# Altium schematic sheet uC.SchDoc
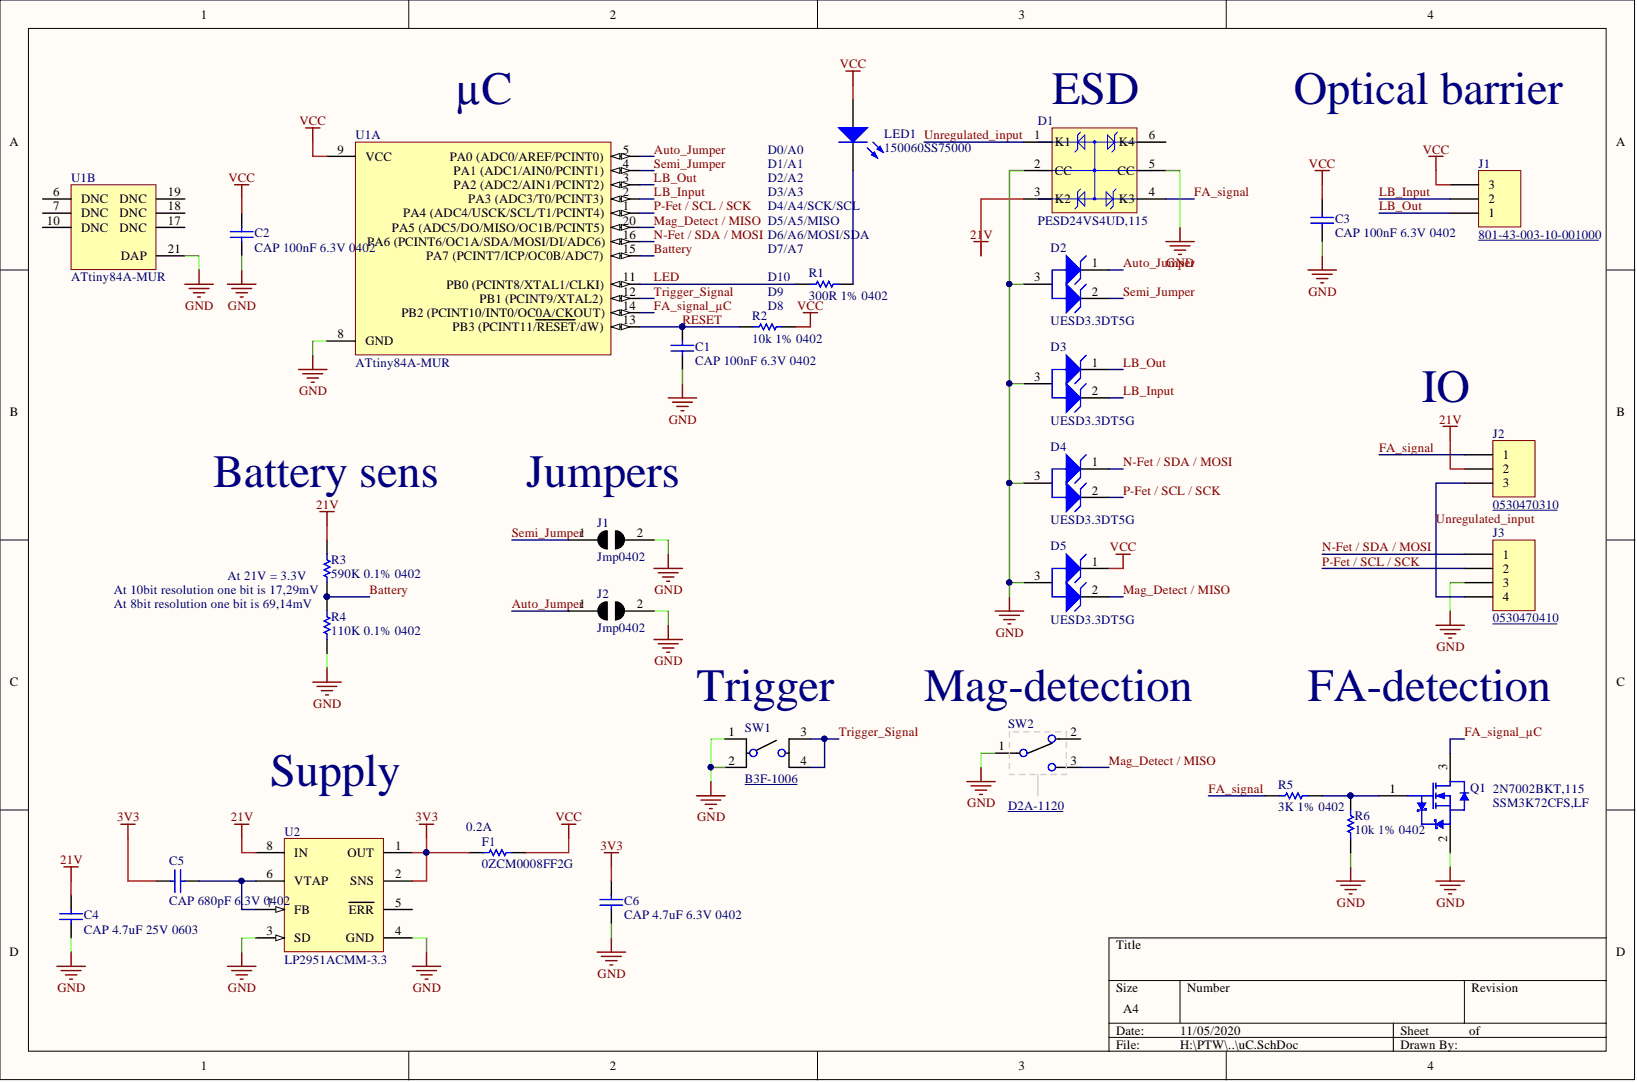
[diagram p0: uC.SchDoc]

## Parts
C1  CAP 100nF 6.3V 0402  value 100nF  [CAPC0402(1005)60_N]
C2  CAP 100nF 6.3V 0402  value 100nF  [CAPC0402(1005)60_N]
C3  CAP 100nF 6.3V 0402  value 100nF  [CAPC0402(1005)60_N]
C4  CAP 4.7uF 25V 0603  value 4.7uF  [CAPC0603(1608)100_N]
C5  CAP 680pF 6.3V 0402  value 680pF  [CAPC0402(1005)60_N]
C6  CAP 4.7uF 6.3V 0402  value 4.7uF  [CAPC0402(1005)60_N]
D1  PESD24VS4UD,115  [NXP-SOT457_N]
D2  UESD3.3DT5G  [ONSC-SOT-723-3-631AA-01_V]
D3  UESD3.3DT5G  [ONSC-SOT-723-3-631AA-01_V]
D4  UESD3.3DT5G  [ONSC-SOT-723-3-631AA-01_V]
D5  UESD3.3DT5G  [ONSC-SOT-723-3-631AA-01_V]
F1  0ZCM0008FF2G  value 0.2A  [RESC0603(1608)_N]
J1  Jmp0402  [Jmp0402(1005)_N]  (no BOM)
J1  801-43-003-10-001000  [801-43-003-10-001000]
J2  Jmp0402  [Jmp0402(1005)_N]  (no BOM)
J2  0530470310  [530470310]
J3  0530470410  [530470410]
LED1  150060SS75000  [0603_A]
Q1  2N7002BKT,115  [NXP-SOT416_B_N]
R1  300R 1% 0402  Case-EIA: 0402  [RESC0402(1005)_N]
R2  10k 1% 0402  Case-EIA: 0402  [RESC0402(1005)_N]
R3  590K 0.1% 0402  [RESC0402(1005)_N]
R4  110K 0.1% 0402  [RESC0402(1005)_N]
R5  3K 1% 0402  Case-EIA: 0402  [RESC0402(1005)_N]
R6  10k 1% 0402  Case-EIA: 0402  [RESC0402(1005)_N]
SW1  B3F-1006  [OMRON B3F-1006]
SW2  D2A-1120  [D2A-1120]
U1  ATtiny84A-MUR  [20M1_N]  (parts A,B)
U2  LP2951ACMM-3.3  [DGK0008A_N]

## Nets
3V3: C5.1 C6.2 F1.1 U2.1(OUT) U2.2(SNS)
21V: C4.2 D1.3(K2) J2.2 R3.2 U2.8(IN)
GND: C1.1 C2.1 C3.1 C4.1 C6.1 D1.2(CC) D1.5(CC) D2.3 D3.3 D4.3 D5.3 J1.2 J2.2 J3.3 Q1.2 R4.1 R6.2 SW1.1 SW1.2 SW2.1 U1.8(GND) U1.21(DAP) U2.3(SD) U2.4(GND)
VCC: C2.2 C3.2 D5.1 F1.2 J1.3 LED1.2 R2.1 U1.9(VCC)
Auto_Jumper: D2.1 J2.1 U1.5
Battery: R3.1 R4.2 U1.15(PA7_(PCINT7/ICP/OC0B/ADC7))
FA_signal: D1.4(K3) J2.1 R5.1
FA_signal_µC: Q1.3 U1.14(PB2_(PCINT10/INT0/OC0A/CKOUT))
LB_Input: D3.2 J1.2 U1.2(PA3_(ADC3/T0/PCINT3))
LB_Out: D3.1 J1.1 U1.3(PA2_(ADC2/AIN1/PCINT2))
LED: R1.2 U1.11(PB0_(PCINT8/XTAL1/CLKI))
Mag_Detect / MISO: D5.2 SW2.3 U1.20(PA5_(ADC5/DO/MISO/OC1B/PCINT5))
N-Fet / SDA / MOSI: D4.1 J3.1 U1.16(PA6_(PCINT6/OC1A/SDA/MOSI/DI/ADC6))
P-Fet / SCL / SCK: D4.2 J3.2 U1.1(PA4_(ADC4/USCK/SCL/T1/PCINT4))
RESET: C1.2 R2.2 U1.13(PB3_(PCINT11/RESET/dW))
Semi_Jumper: D2.2 J1.1 U1.4(PA1_(ADC1/AIN0/PCINT1))
Trigger_Signal: SW1.3 SW1.4 U1.12(PB1_(PCINT9/XTAL2))
Unregulated_input: D1.1(K1) J2.3 J3.4
NetC5_2: C5.2 U2.6(VTAP) U2.7(FB)
NetLED1_1: LED1.1 R1.1
NetQ1_1: Q1.1 R5.2 R6.1

Not connected: D1 6(K4); SW2 2 SH; U1 6(DNC) 7(DNC) 10(DNC) 17(DNC) 18(DNC) 19(DNC); U2 5(ERR)

## Library data of the parts
C1-C3 = CMP-1034-02063-1: CAP 100nF 6.3V -20% to +80% 0402 (1005 Metric) Thickness 0.6mm SMD; Case-EIA: 0402; Case-Metric: 1005; Component Kind: Standard; Library Name: Altium Content Vault; Max Thickness: 0.6 mm; Rated Voltage: 6.3 V; Technology: SMT; Tolerance: -20% to +80%
  C2: Value: 100nF
C4 = CMP-1035-02789-1: CAP 4.7uF 25V ±20% 0603 (1608 Metric) Thickness 1mm SMD; Case-EIA: 0603; Case-Metric: 1608; Tolerance: Â±20%; Component Kind: Standard; Library Name: Altium Content Vault; Max Thickness: 1 mm; Rated Voltage: 25 V; Technology: SMT
C5 = CMP-1034-01543-1: CAP 680pF 6.3V ±20% 0402 (1005 Metric) Thickness 0.6mm SMD; Case-EIA: 0402; Case-Metric: 1005; Tolerance: Â±20%; Component Kind: Standard; Library Name: Altium Content Vault; Max Thickness: 0.6 mm; Rated Voltage: 6.3 V; Technology: SMT
C6 = CMP-1034-02137-1: CAP 4.7uF 6.3V ±20% 0402 (1005 Metric) Thickness 0.6mm SMD; Case-EIA: 0402; Case-Metric: 1005; Tolerance: Â±20%; Component Kind: Standard; Library Name: Altium Content Vault; Max Thickness: 0.6 mm; Rated Voltage: 6.3 V; Technology: SMT
D1 = PESD24VS4UD,115: TVS Diodes / ESD Suppressors 24V - 4-fold unidirectional ESD protection array; Component Kind: Standard; Library Name: ESD.SchLib; Supplier 1: Digi-Key; Supplier 2: Farnell; Supplier 3: Mouser; Supplier Part Number 1: 1727-3926-1-ND; Supplier Part Number 2: 1510707; Supplier Part Number 3: 771-PESD24VS4UD115
D2-D5 = CMP-1063-00209-1: ESD Protection Diode, 3-Pin SOT-723, Pb-Free, Tape and Reel; Manufacturer: On Semiconductor; Direction: Unidirectional; IR Max (uA): 1; Number of Lines: 2; V(BR) Min (V): 5; VRWM Max (V): 3.3; Component Kind: Standard; ComponentLink1Description: Manufacturer URL; ComponentLink1URL: http://www.onsemi.com/; ComponentLink2Description: Datasheet; ComponentLink2URL: http://www.onsemi.cn/pub_link/Collateral/UESD3.3DT5G-D.PDF; ComponentLink3Description: Package Specification; ComponentLink3URL: http://www.onsemi.com/pub_link/Collateral/631AA.PDF; DatasheetVersion: Rev. 4; Library Name: Altium Content Vault; Mounting Technology: Surface Mount; PackageDescription: 3-Pin Small Outline Transistor, Body 1.2 x 0.8 mm, Pitch 0.4 mm; PackageReference: SOT-723-3-631AA-01; PackageVersion: Rev. D, 08/2009; Packing: Tape and Reel; PartNumber: UESD3.3DT5G; RoHS: Pb-Free; Supplier 1: Digi-Key; Supplier 2: Farnell; Supplier 3: Mouser; Supplier Part Number 1: UESD3.3DT5GOSCT-ND; Supplier Part Number 2: 2533288; Supplier Part Number 3: 863-UESD3.3DT5G
F1 = CMP-1012-00436-1: PTC 80mA 15V 0603 Trip: 200mA; Case-EIA: 0603; Case-Metric: 1608; Power: 0.1A; Tolerance: 1 %; Component Kind: Standard; Library Name: Altium Content Vault; Supplier 1: Digi-Key; Supplier 2: Mouser; Supplier Part Number 1: 507-1817-1-ND; Supplier Part Number 2: 530-0ZCM0008FF2G; Technology: PTC
J1 J2 = Jmp0402: Jumper 0402 with 150µm space; Library Name: Jumpers.SchLib
J1 = 801-43-003-10-001000: 3 Pim header socket 7mm height; Component Kind: Standard; ComponentLink1Description: Datasheet; ComponentLink1URL: https://www.mill-max.com/products/socket/801-xx-xxx-10-001000/801-43-003-10-001000?s_term=801-43-003-10-001000&s_type=Quick%2FProduct%2FPart+Number+Search; Library Name: Connector.SchLib; Supplier 1: Digi-Key; Supplier Part Number 1: ED6350-03-ND
J2 = 0530470310: Molex Picoblade, 3Pin THT Straight; Component Kind: Standard; ComponentLink1Description: Datasheet; ComponentLink1URL: http://www.molex.com/pdm_docs/sd/530470310_sd.pdf; Library Name: Connector.SchLib; Supplier 1: Digi-Key; Supplier 2: Farnell; Supplier 3: Mouser; Supplier Part Number 1: WM1732-ND; Supplier Part Number 2: 9732853; Supplier Part Number 3: 538-53047-0310
J3 = 0530470410: Molex Picoblade, 4Pin THT Straight; Component Kind: Standard; ComponentLink1Description: Datasheet; ComponentLink1URL: http://www.molex.com/pdm_docs/sd/530470410_sd.pdf; Library Name: Connector.SchLib; Supplier 1: Digi-Key; Supplier 2: Farnell; Supplier 3: Mouser; Supplier Part Number 1: WM1733-ND; Supplier Part Number 2: 9733060; Supplier Part Number 3: 538-53047-0410
LED1 = CMP-1426-00004-1: SMD mono-color Chip LED, WL-SMCW, Super Red; Manufacturer: Wuerth Elektronik; Color: Super Red; Component Kind: Standard; ComponentLink1Description: Manufacturer URL; ComponentLink1URL: http://www.we-online.com; ComponentLink2Description: Datasheet; ComponentLink2URL: http://katalog.we-online.com/led/datasheet/150060SS75000.pdf; Library Name: Altium Content Vault; PackageReference: 0603_A; PartNumber: 150060SS75000
Q1 = CMP-1259-00271-1: N-Channel Trench MOSFET, 60 V, 0.29 A, -55 to 150 degC, 3-Pin SOT416, Tape and Reel; Manufacturer: NXP Semiconductors; Automotive Qualified: Y; Channel Type: N; ID [max] (A): 0.29; IDM [max] (A): 1.2; Packing: Tape & Reel; VDS [max] (V): 60; VGSth [typ] (V): 1.6; Code_JEITA: SC-75; Component Kind: Standard; ComponentLink1Description: Manufacturer URL; ComponentLink1URL: http://www.nxp.com/; ComponentLink2Description: Datasheet; ComponentLink2URL: http://www.nxp.com/documents/data_sheet/2N7002BKT.pdf; ComponentLink3Description: Package Specification; ComponentLink3URL: http://www.nxp.com/documents/data_sheet/2N7002PT.pdf; DatasheetVersion: Rev. 1; Library Name: Altium Content Vault; Mounting Technology: Surface Mount; PackageDescription: 3-Pin Small Outline Transistor Package, Body 1.6 x 0.8 mm, Pitch 0.5 mm; PackageReference: SOT416_B; PackageVersion: 06-03-16, 03/2006; PartNumber: 2N7002BKT,115; Supplier 1: Digi-Key; Supplier 2: Mouser; Supplier Part Number 1: SSM3K72CFSLFCT-ND; Supplier Part Number 2: 757-SSM3K72CFSLF
R1 = CMP-1011-00623-1: 300R 0.063W 1% 0402 (1005 Metric)  SMD; Case-Metric: 1005; Value: 10k Ohm; Component Kind: Standard; Library Name: Altium Content Vault; Power: 0.063 W; Technology: SMT; Tolerance: 1 %
R2 R6 = CMP-1011-00623-1: 10k 0.063W 1% 0402 (1005 Metric)  SMD; Case-Metric: 1005; Value: 10k Ohm; Component Kind: Standard; Library Name: Altium Content Vault; Power: 0.063 W; Technology: SMT; Tolerance: 1 %
R3 = CMP-1011-00823-1: 590K 0.063W 0.1% 0402 (1005 Metric)  SMD; Case-EIA: 0402; Case-Metric: 1005; Value: 590000.00 Ohm; Component Kind: Standard; Library Name: Altium Content Vault; Power: 0.063 W; Technology: SMT; Tolerance: 0.1 %
R4 = CMP-1011-00740-1: 110K 0.063W 0.1% 0402 (1005 Metric)  SMD; Case-EIA: 0402; Case-Metric: 1005; Value: 110000.00 Ohm; Component Kind: Standard; Library Name: Altium Content Vault; Power: 0.063 W; Technology: SMT; Tolerance: 0.1 %
R5 = CMP-1011-00562-1: 3K 0.063W 1% 0402 (1005 Metric)  SMD; Case-Metric: 1005; Value: 3000.00 Ohm; Component Kind: Standard; Library Name: Altium Content Vault; Power: 0.063 W; Technology: SMT; Tolerance: 1 %
SW1 = B3F-1006: Tactile Switch Omron B3F-1006; Contact Resistance: 50mOhm; Travel: 0.25mm; Component Kind: Standard; ComponentLink1Description: Datasheet; ComponentLink1URL: https://omronfs.omron.com/en_US/ecb/products/pdf/en-b3f.pdf; Library Name: Switch.SchLib; Manufacture specification: B3F-1006; Supplier 1: Digi-Key; Supplier 2: Farnell; Supplier 3: Mouser; Supplier Part Number 1: SW950-ND; Supplier Part Number 2: 1960938; Supplier Part Number 3: 653-B3F-1006
SW2 = D2A-1120: SWITCH TACTILE Omron D2A 0.1A 30V; Manufacturer Part: MK-12C02-PB; Actuator Type: Standard; Contact resistence: 50mΩ max.; Current Rating: 0.1A @ 30VDC; Insulation: 100MΩ min.; Operating force: 50gf±1gf; Switch Function: On-On; Component Kind: Standard; ComponentLink1Description: Manufacturer URL; ComponentLink1URL: http://components.omron.eu/; ComponentLink2Description: Datasheet; ComponentLink2URL: https://omronfs.omron.com/en_US/ecb/products/pdf/en-d2a.pdf; Library Name: Switch.SchLib; Manufacturer: Omron; Mounting Type: Through Hole; Operating Temperature: -10°C ~ 80°C; Supplier 1: Digi-Key; Supplier 2: Mouser; Supplier Part Number 1: SW833-ND; Supplier Part Number 2: 653-D2A-1120
U1 = CMP-0093-00271-1: 8-bit AVR Microcontroller,  20MHz, 1.8-5.5V, 8KB Flash, 512 Bytes EEPROM, 512 Bytes SRAM, 20-pin MLF, Industrial Grade (-40°C to 85°C), Pb-Free, Reel; Code_JEDEC: MO-220WGGD-5; Component Kind: Standard; ComponentLink1Description: Manufacturer URL; ComponentLink1URL: http://www.atmel.com/; ComponentLink2Description: ATtiny24A/44A/84A Datasheet; ComponentLink2URL: http://www.atmel.com/dyn/resources/prod_documents/doc8183.pdf; ComponentLink3Description: ATtiny24A/44A/84A Summary; ComponentLink3URL: http://www.atmel.com/dyn/resources/prod_documents/8183S.pdf; DatasheetVersion: revD, Apr-2011; Library Name: Altium Content Vault; PackageDescription: 20-pad, 4 x 4 x 0.8 mm Body, Lead Pitch 0.50 mm, 2.6 mm Exposed Pad, Micro Lead Frame Package (MLF); PackageReference: 20M1; PackageVersion: revA, Oct-2004; PartId: 1; Supplier 1: Digi-Key; Supplier 2: Mouser; Supplier Part Number 1: ATTINY84A-MURCT-ND; Supplier Part Number 2: 556-ATTINY84A-MUR
U2 = CMP-0062-02229-3: Series of Adjustable Micropower Voltage Regulators, 8-pin Mini SOIC; Manufacturer: Texas Instruments; CompType: LDO IC; PackageTopMarking: ZXTT*L0CA; PowerWise: N; Code_JEDEC: MO-187-AA; Component Kind: Standard; ComponentLink1Description: Datasheet URL; ComponentLink1URL: http://www.ti.com/general/docs/lit/getliterature.tsp?genericPartNumber=LP2951-N&fileType=pdf; Library Name: Altium Content Vault; PackageDescription: MINI SOIC, .118 x .118 x .034in, 8 Lead, .0256in Pitch; PackageReference: DGK0008A; PinCount: 8; RoHS: N; Supplier 1: Digi-Key; Supplier 2: Farnell; Supplier 3: Mouser; Supplier Part Number 1: LP2951ACMM-3.3/NOPBCT-ND; Supplier Part Number 2: 3007582; Supplier Part Number 3: 926-LP2951ACMM33NOPB
